# Altium schematic sheet Z80_Interface.SchDoc
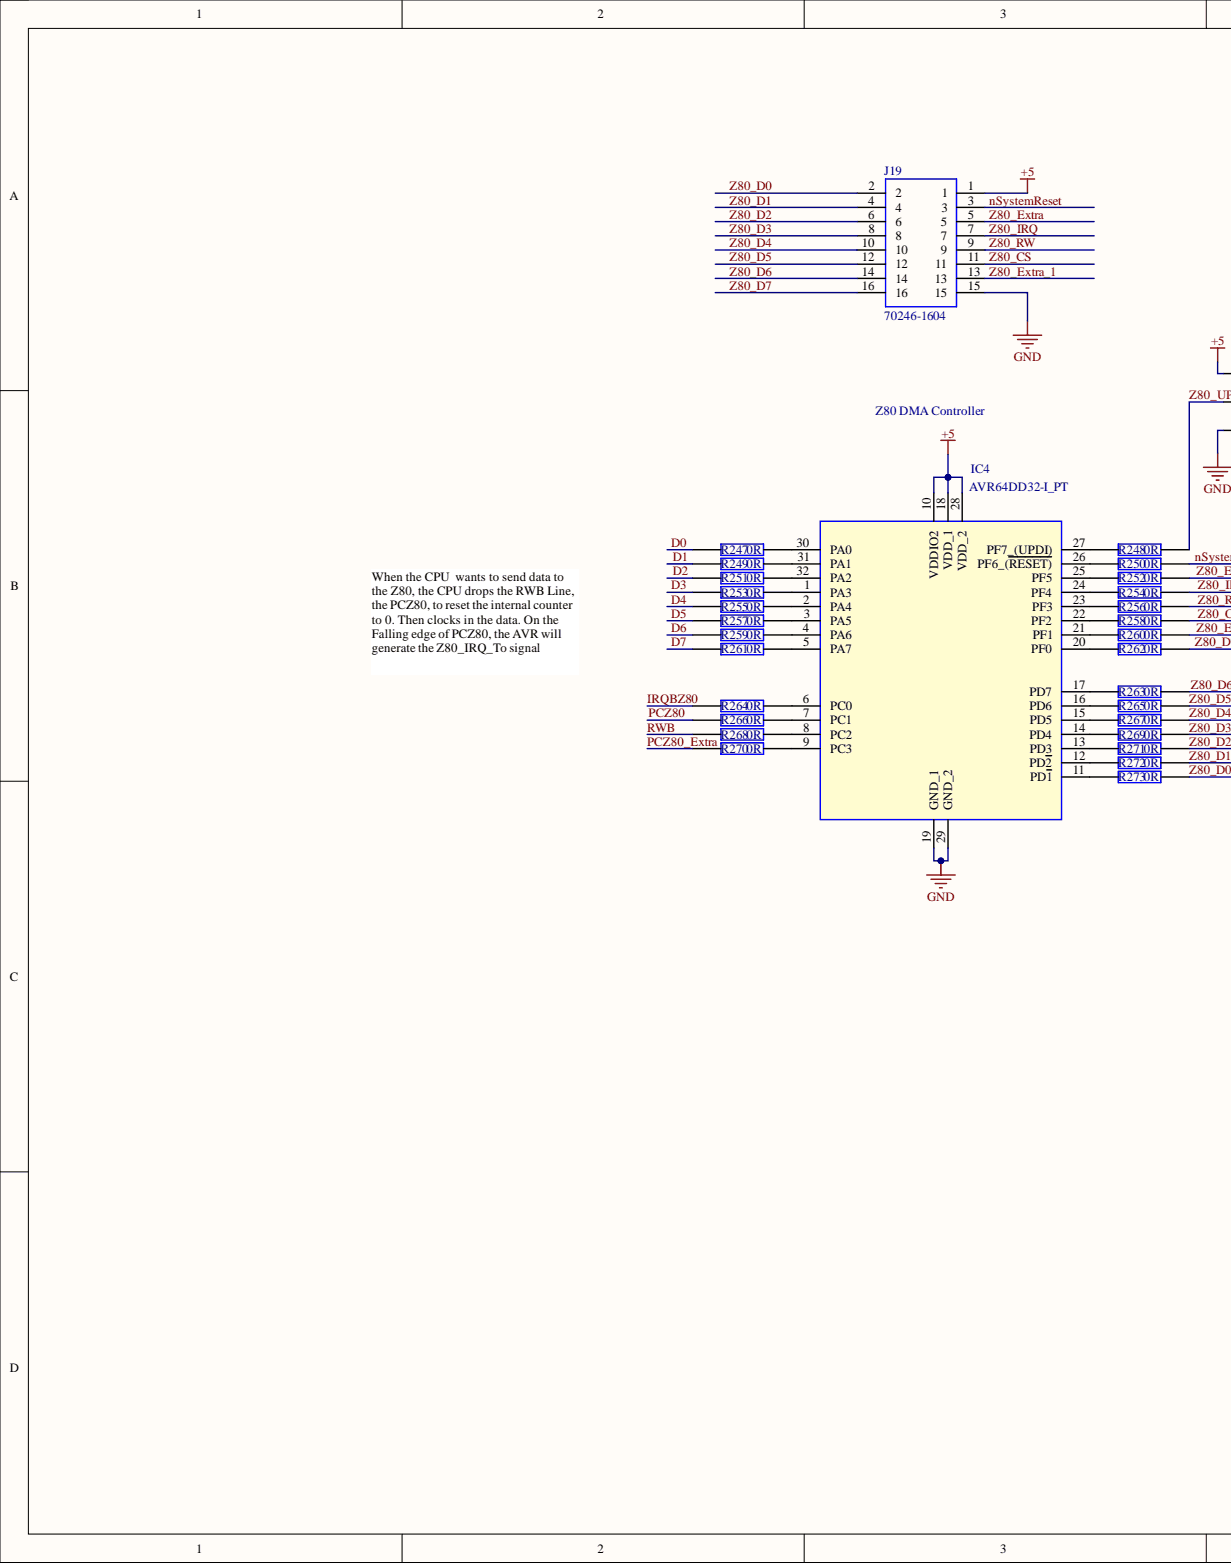
[diagram p0: Z80_Interface.SchDoc, left]
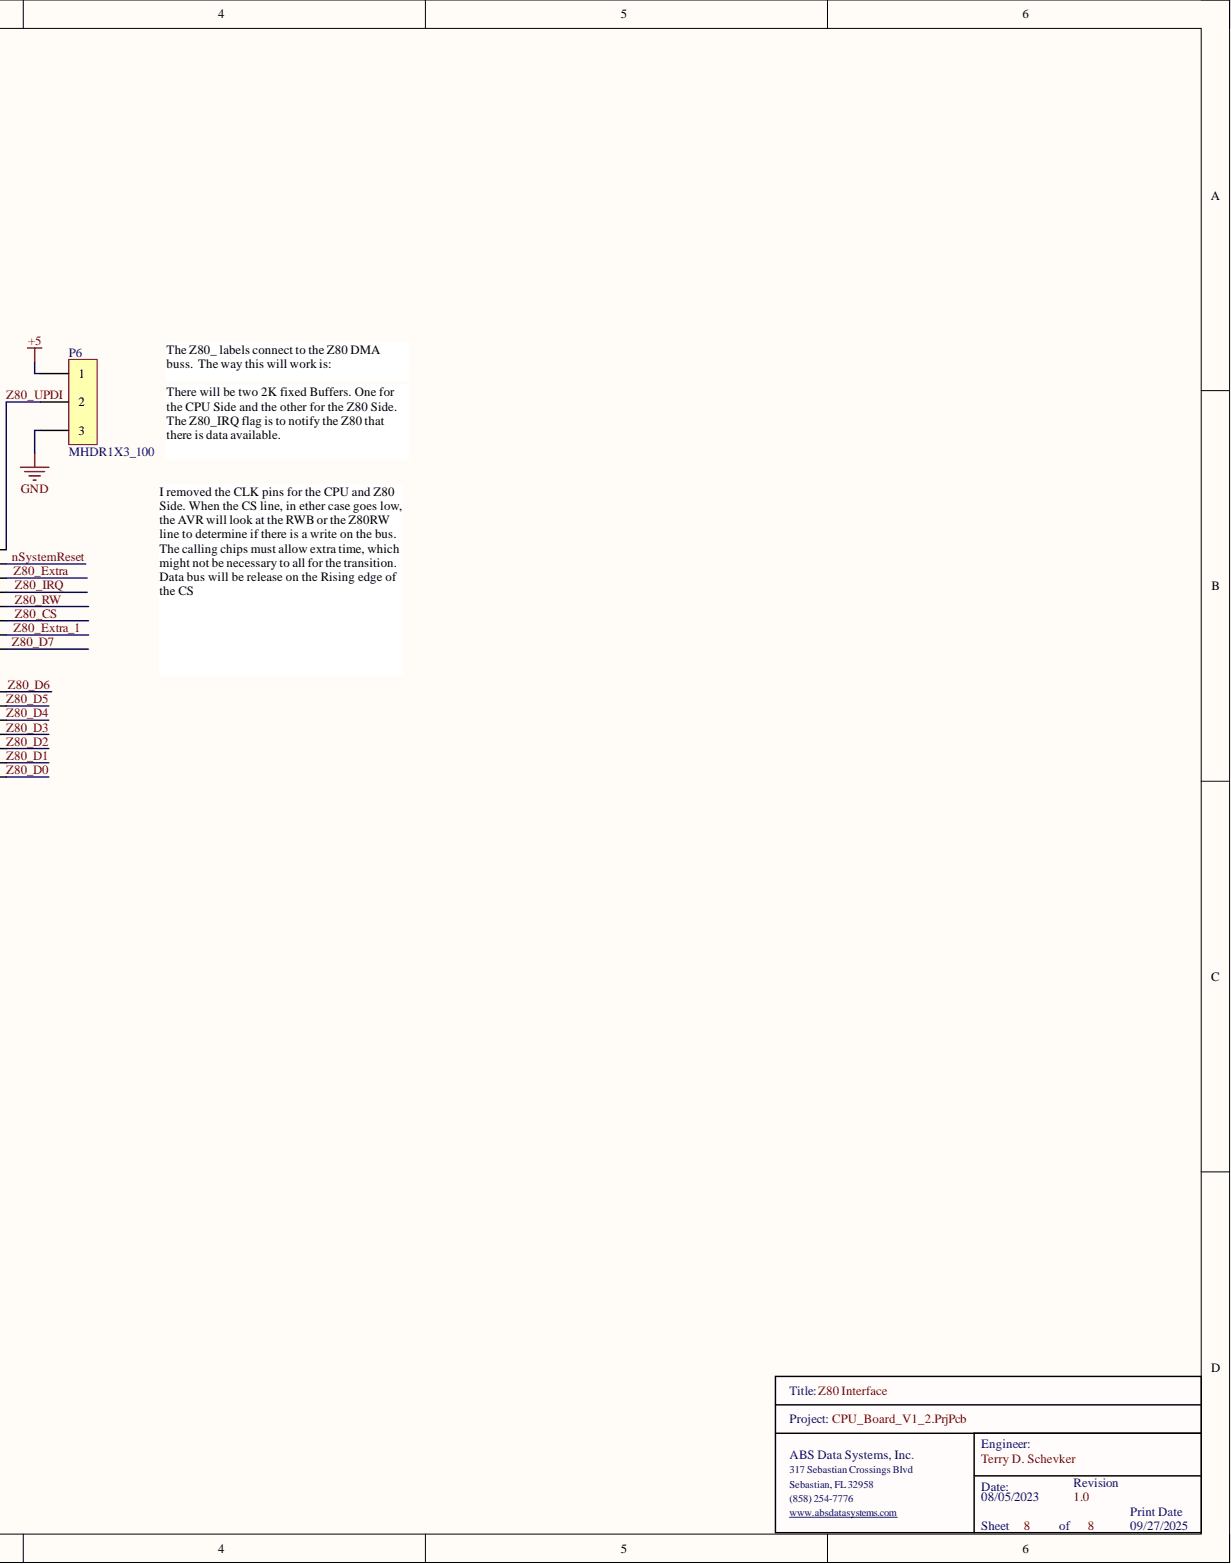
[diagram p1: Z80_Interface.SchDoc, right]

## Parts
IC4  AVR64DD32-I_PT  [QFP80P900X900X120-32N]
J19  70246-1604  [SHDR16W99P254_2X8_2794X889X927P]
P6  MHDR1X3_100  [MHDR1X3_100]
R247  0R  [0603]  (value not drawn)
R248  0R  [0603]  (value not drawn)
R249  0R  [0603]  (value not drawn)
R250  0R  [0603]  (value not drawn)
R251  0R  [0603]  (value not drawn)
R252  0R  [0603]  (value not drawn)
R253  0R  [0603]  (value not drawn)
R254  0R  [0603]  (value not drawn)
R255  0R  [0603]  (value not drawn)
R256  0R  [0603]  (value not drawn)
R257  0R  [0603]  (value not drawn)
R258  0R  [0603]  (value not drawn)
R259  0R  [0603]  (value not drawn)
R260  0R  [0603]  (value not drawn)
R261  0R  [0603]  (value not drawn)
R262  0R  [0603]  (value not drawn)
R263  0R  [0603]  (value not drawn)
R264  0R  [0603]  (value not drawn)
R265  0R  [0603]  (value not drawn)
R266  0R  [0603]  (value not drawn)
R267  0R  [0603]  (value not drawn)
R268  0R  [0603]  (value not drawn)
R269  0R  [0603]  (value not drawn)
R270  0R  [0603]  (value not drawn)
R271  0R  [0603]  (value not drawn)
R272  0R  [0603]  (value not drawn)
R273  0R  [0603]  (value not drawn)

## Nets
+5: IC4.10(VDDIO2) IC4.18(VDD_1) IC4.28(VDD_2) J19.1 P6.1
GND: IC4.19(GND_1) IC4.29(GND_2) J19.15 P6.3
D0: R247.1
D1: R249.1
D2: R251.1
D3: R253.1
D4: R255.1
D5: R257.1
D6: R259.1
D7: R261.1
IRQBZ80: R264.1
nSystemReset: J19.3 R250.2
PCZ80: R266.1
PCZ80_Extra: R270.1
RWB: R268.1
Z80_CS: J19.11 R258.2
Z80_D0: J19.2 R273.2
Z80_D1: J19.4 R272.2
Z80_D2: J19.6 R271.2
Z80_D3: J19.8 R269.2
Z80_D4: J19.10 R267.2
Z80_D5: J19.12 R265.2
Z80_D6: J19.14 R263.2
Z80_D7: J19.16 R262.2
Z80_Extra: J19.5 R252.2
Z80_Extra_1: J19.13 R260.2
Z80_IRQ: J19.7 R254.2
Z80_RW: J19.9 R256.2
Z80_UPDI: P6.2 R248.2
NetIC4_1: IC4.1(PA3) R253.2
NetIC4_2: IC4.2(PA4) R255.2
NetIC4_3: IC4.3(PA5) R257.2
NetIC4_4: IC4.4(PA6) R259.2
NetIC4_5: IC4.5(PA7) R261.2
NetIC4_6: IC4.6(PC0) R264.2
NetIC4_7: IC4.7(PC1) R266.2
NetIC4_8: IC4.8(PC2) R268.2
NetIC4_9: IC4.9(PC3) R270.2
NetIC4_11: IC4.11(PD1) R273.1
NetIC4_12: IC4.12(PD2) R272.1
NetIC4_13: IC4.13(PD3) R271.1
NetIC4_14: IC4.14(PD4) R269.1
NetIC4_15: IC4.15(PD5) R267.1
NetIC4_16: IC4.16(PD6) R265.1
NetIC4_17: IC4.17(PD7) R263.1
NetIC4_20: IC4.20(PF0) R262.1
NetIC4_21: IC4.21(PF1) R260.1
NetIC4_22: IC4.22(PF2) R258.1
NetIC4_23: IC4.23(PF3) R256.1
NetIC4_24: IC4.24(PF4) R254.1
NetIC4_25: IC4.25(PF5) R252.1
NetIC4_26: IC4.26(PF6_(RESET)) R250.1
NetIC4_27: IC4.27(PF7_(UPDI)) R248.1
NetIC4_30: IC4.30(PA0) R247.2
NetIC4_31: IC4.31(PA1) R249.2
NetIC4_32: IC4.32(PA2) R251.2

## Library data of the parts
IC4 = AVR64DD32-I_PT: Integrated Circuit; Manufacturer_Name: Microchip; Manufacturer_Part_Number: AVR64DD32-I/PT | MULTI-VOLTAGE I/O; Component Kind: Standard; Datasheet Link: https://www.microchip.com/en-us/product/AVR64DD32; Height: 1.2mm; Library Name: CPU_Memory.SchLib
J19 = 70246-1604: Molex C-GRID 70246, 2.54mm Pitch, 16 Way, 2 Row, Straight PCB Header, Through Hole; Geometry.Height: 9.27mm; Component Kind: Standard; Datasheet Link: https://www.molex.com/pdm_docs/sd/702461604_sd.pdf; Library Name: Connectors.SchLib; Manufacturer_Name: Molex; Manufacturer_Part_Number: 70246-1604; Mouser Part Number: 538-70246-1604; Mouser Price/Stock: https://www.mouser.co.uk/ProductDetail/Molex/70246-1604?qs=KUIzHt%2Fe91njhb67Cjmalw%3D%3D
P6 = MHDR1X3_100: Header, 3-Pin; Component Kind: Standard; LatestRevisionDate: 17-Jul-2002; LatestRevisionNote: Re-released for DXP Platform.; Library Name: Connectors.SchLib; Publisher: Altium Limited
R247-R273 = RC0603JR-070RL: YAGEO 0603 0 ohm; Manufacturer_Name: KEMET; Geometry.Height: 0.55mm; Component Kind: Standard; Datasheet Link: https://datasheet.datasheetarchive.com/originals/distributors/Datasheets_SAMA/902f9e387b938f871d31120f5fc1d65e.pdf; Library Name: Resistors.SchLib; Manufacturer_Part_Number: RC0603JR-070RL; Mouser Part Number: 603-RC0603JR-070RL; Mouser Price/Stock: https://www.mouser.co.uk/ProductDetail/YAGEO/RC0603JR-070RL?qs=2cAdsCoAWRGWyPcuXgGiXQ%3D%3D
